# Altium schematic sheet ICWSHLP2_Head_2x2_ASIC0.SchDoc(ICWSHLP2_Head_2x2_ASIC0)
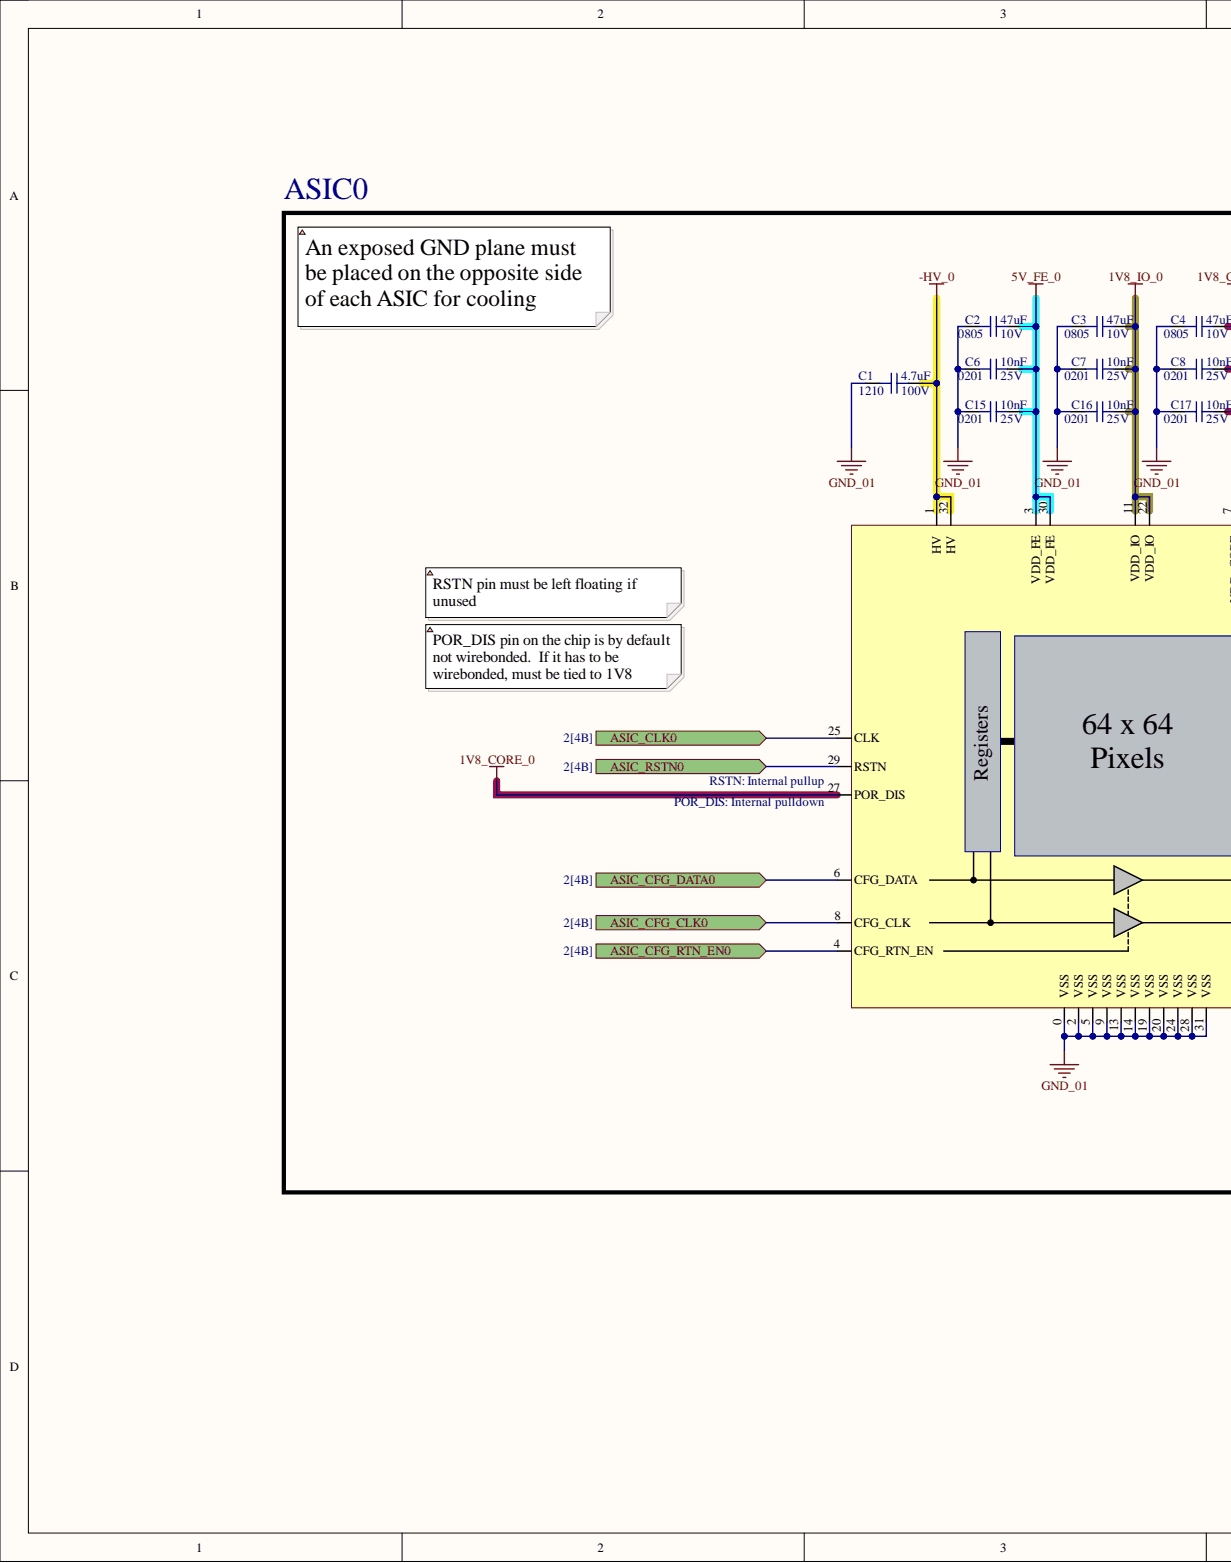
[diagram p0: ICWSHLP2_Head_2x2_ASIC0.SchDoc, left]
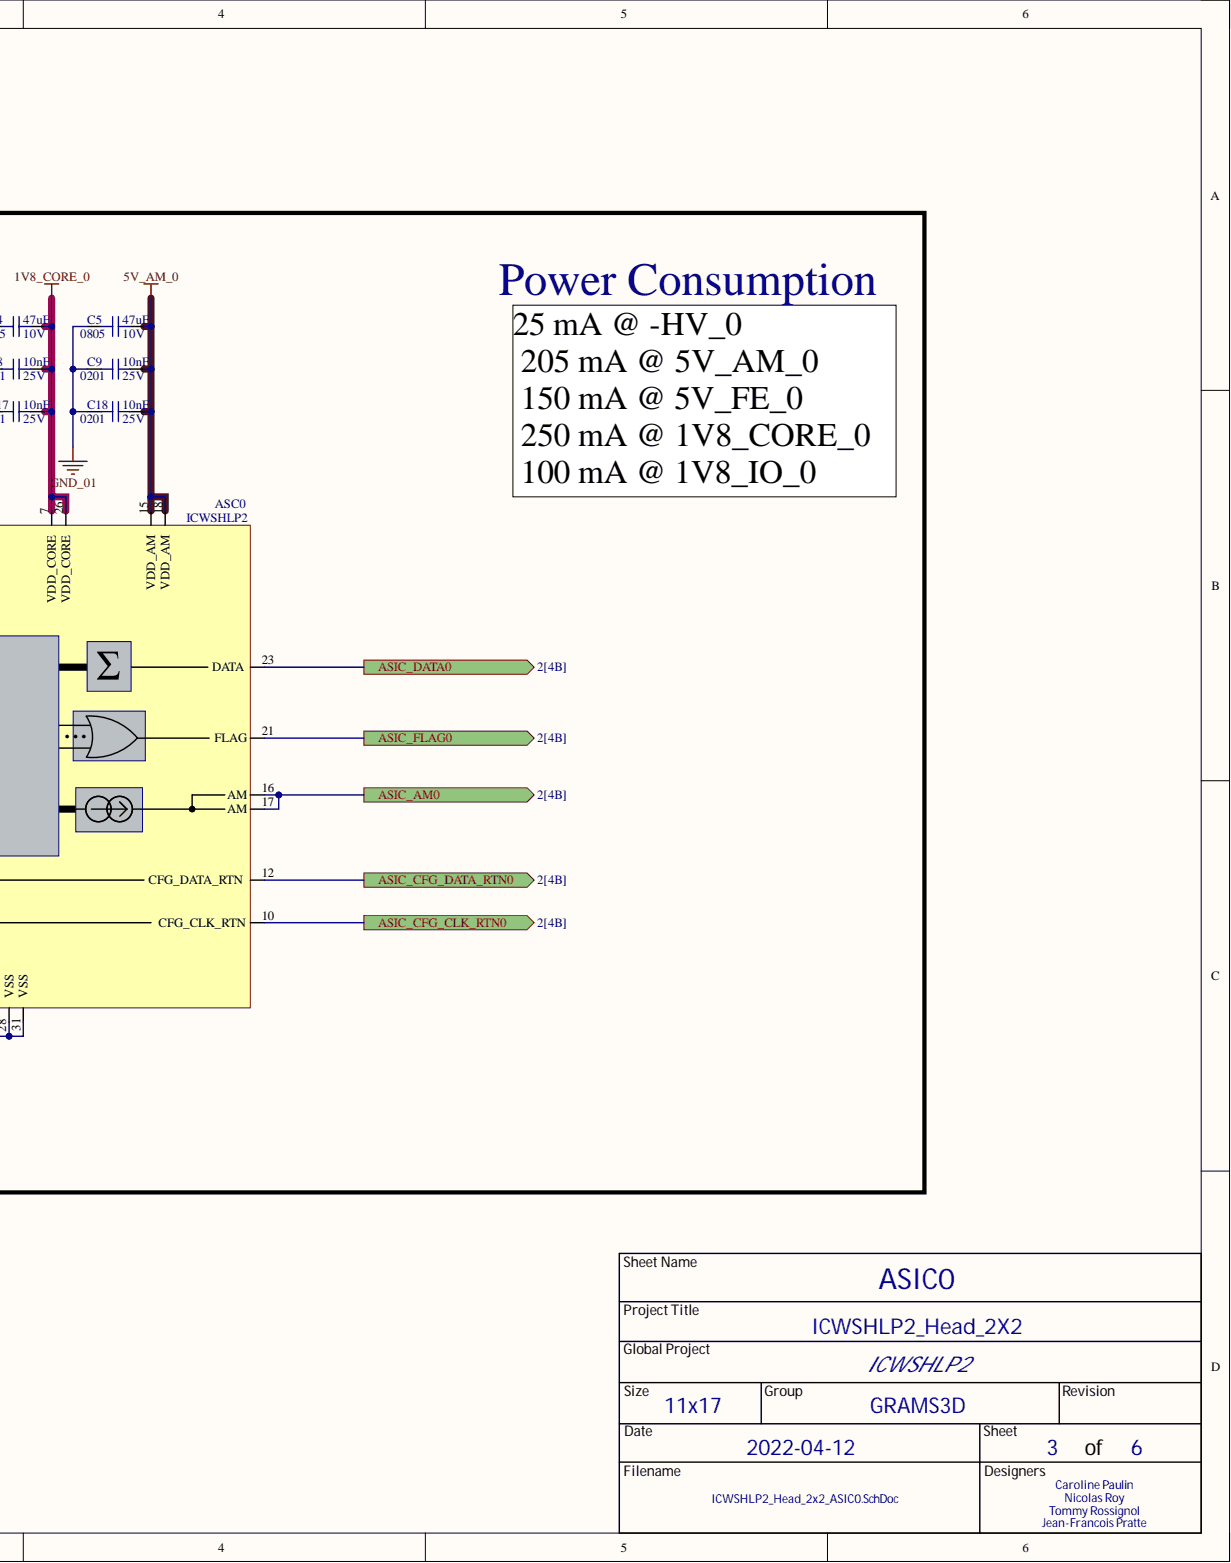
[diagram p1: ICWSHLP2_Head_2x2_ASIC0.SchDoc, right]

## Parts
ASC0  ICWSHLP2  [ASC_ICWSHLP2]
C1  value 4.7uF  Package: 1210  Voltage Rating: 100V  [CAP_CER_1210]  (hidden comment: GCM32DC72A475KE02L)
C2  value 47uF  Package: 0805  Voltage Rating: 10V  [CAP_CER_0805]  (hidden comment: GRM21BR61A476ME15L)
C3  value 47uF  Package: 0805  Voltage Rating: 10V  [CAP_CER_0805]  (hidden comment: GRM21BR61A476ME15L)
C4  value 47uF  Package: 0805  Voltage Rating: 10V  [CAP_CER_0805]  (hidden comment: GRM21BR61A476ME15L)
C5  value 47uF  Package: 0805  Voltage Rating: 10V  [CAP_CER_0805]  (hidden comment: GRM21BR61A476ME15L)
C6  value 10nF  Package: 0201  Voltage Rating: 25V  [CAP_CER_0201]  (hidden comment: TMK063B7103KP-F)
C7  value 10nF  Package: 0201  Voltage Rating: 25V  [CAP_CER_0201]  (hidden comment: TMK063B7103KP-F)
C8  value 10nF  Package: 0201  Voltage Rating: 25V  [CAP_CER_0201]  (hidden comment: TMK063B7103KP-F)
C9  value 10nF  Package: 0201  Voltage Rating: 25V  [CAP_CER_0201]  (hidden comment: TMK063B7103KP-F)
C15  value 10nF  Package: 0201  Voltage Rating: 25V  [CAP_CER_0201]  (hidden comment: TMK063B7103KP-F)
C16  value 10nF  Package: 0201  Voltage Rating: 25V  [CAP_CER_0201]  (hidden comment: TMK063B7103KP-F)
C17  value 10nF  Package: 0201  Voltage Rating: 25V  [CAP_CER_0201]  (hidden comment: TMK063B7103KP-F)
C18  value 10nF  Package: 0201  Voltage Rating: 25V  [CAP_CER_0201]  (hidden comment: TMK063B7103KP-F)

## Ports
ASIC_AM0, ASIC_CFG_CLK0, ASIC_CFG_CLK_RTN0, ASIC_CFG_DATA0, ASIC_CFG_DATA_RTN0, ASIC_CFG_RTN_EN0, ASIC_CLK0, ASIC_DATA0, ASIC_FLAG0, ASIC_RSTN0

## Nets
1V8_CORE_0: ASC0.7(VDD_CORE) ASC0.26(VDD_CORE) ASC0.27(POR_DIS) C4.2 C8.1 C17.1
1V8_IO_0: ASC0.11(VDD_IO) ASC0.22(VDD_IO) C3.2 C7.1 C16.1
5V_AM_0: ASC0.15(VDD_AM) ASC0.18(VDD_AM) C5.2 C9.1 C18.1
5V_FE_0: ASC0.3(VDD_FE) ASC0.30(VDD_FE) C2.2 C6.1 C15.1
-HV_0: ASC0.1(HV) ASC0.32(HV) C1.1
GND_01: ASC0.0(VSS) ASC0.2(VSS) ASC0.5(VSS) ASC0.9(VSS) ASC0.13(VSS) ASC0.14(VSS) ASC0.19(VSS) ASC0.20(VSS) ASC0.24(VSS) ASC0.28(VSS) ASC0.31(VSS) C1.2 C2.1 C3.1 C4.1 C5.1 C6.2 C7.2 C8.2 C9.2 C15.2 C16.2 C17.2 C18.2
ASIC_AM0: ASC0.16(AM) ASC0.17(AM) ; port ASIC_AM0
ASIC_CFG_CLK0: ASC0.8(CFG_CLK) ; port ASIC_CFG_CLK0
ASIC_CFG_CLK_RTN0: ASC0.10(CFG_CLK_RTN) ; port ASIC_CFG_CLK_RTN0
ASIC_CFG_DATA0: ASC0.6(CFG_DATA) ; port ASIC_CFG_DATA0
ASIC_CFG_DATA_RTN0: ASC0.12(CFG_DATA_RTN) ; port ASIC_CFG_DATA_RTN0
ASIC_CFG_RTN_EN0: ASC0.4(CFG_RTN_EN) ; port ASIC_CFG_RTN_EN0
ASIC_CLK0: ASC0.25(CLK) ; port ASIC_CLK0
ASIC_DATA0: ASC0.23(DATA) ; port ASIC_DATA0
ASIC_FLAG0: ASC0.21(FLAG) ; port ASIC_FLAG0
ASIC_RSTN0: ASC0.29(RSTN) ; port ASIC_RSTN0

## Library data of the parts
ASC0 = ASC_ICWSHLP2: Rev 2. CMOS SPAD Readout for 3D PDC in Low Power Applications.; Manufacturer #1: TSMC 180 nm BCD; GRAMS Lib Spec: 2.7; Magnetic Material: No; Polarized: Yes; Voltage Rating: 1.8V/5.0V; Assembly Type: Wirebonding; Component Kind: Standard; Library Name: GRAMS_MASTER_LIB_SCH.SchLib; Package: Bare Die; Part Number #1: ICWSHLP2; Supplier 1: TSMC; Supplier Part Number 1: ICWSHLP2; Temperature: -200 to 125 degC; CreatedBy/Date: Nicolas Roy/24 sept. 2018; VerifiedBy: Nicolas Roy
C1 = CAP_CER_GCM32DC72A475KE02L: CAP CER 4.7UF 100V X7S 1210; Manufacturer #1: Murata Electronics; Cryo: UNKNOWN; GRAMS Lib Spec: 2.8; Polarized: NO; Tolerance: 5%; Assembly Type: SMD; Component Kind: Standard; HelpURL: https://search.murata.co.jp/Ceramy/image/img/A01X/G101/ENG/GCM32DC72A475KE02-01.pdf; Magnetic Material: UNKNOWN; Part Number #1: GCM32DC72A475KE02L; Solder Paste Type: UNKNOWN; Stencil Thickness (Recommended): UNKNOWN; Supplier 1: Digi-Key; Supplier Part Number 1: 490-14484-1-ND; Temperature: -55°C ~ 125°C; CreatedBy/Date: Thomas G-C 2021-07-01; Library Name: Lib_temp_Thomas_GC.SchLib
C2-C5 = CAP_CER_GRM21BR61A476ME15L: CAP - CER 47uF 20% 10V X5R - 0805; Manufacturer #1: Murata; Cryo: UNKNOWN; GRAMS Lib Spec: 2.8; Polarized: No; Assembly Type: SMD; Component Kind: Standard; HelpURL: http://search.murata.co.jp/Ceramy/image/img/A01X/G101/ENG/GRM21BR61A476ME15-01.pdf; Library Name: GRAMS_MASTER_LIB_SCH.SchLib; Magnetic Material: UNKNOWN; Part Number #1: GRM21BR61A476ME15L; Solder Paste Type: UNKNOWN; Stencil Thickness (Recommended): UNKNOWN; Supplier 1: Digi-Key; Supplier Part Number 1: 490-9961-1-ND; Temperature: -55°C ~ 85°C; Tolerance: 20%; CreatedBy/Date: Joel Lemay 2018-06-19; VerifiedBy: Caroline Paulin - 7 sept 2018
C6-C9 C15-C18 = CAP_CER_TMK063B7103KP-F: CAP - CER 10nF 10% 25V X7R - 0201; Manufacturer #1: Taiyo Yuden; Cryo: UNKNOWN; GRAMS Lib Spec: 2.8; Polarized: No; Solder Paste Type: TYPE 3; Stencil Thickness (Recommended): MAX 5 MILS; Assembly Type: SMD; Component Kind: Standard; HelpURL: http://ds.yuden.co.jp/TYCOMPAS/ut/detail.do?productNo=TMK063B7103KP-F&fileName=TMK063B7103KP-F_SS&mode=specSheetDownload; Library Name: GRAMS_MASTER_LIB_SCH.SchLib; Magnetic Material: UNKNOWN; Part Number #1: TMK063B7103KP-F; Supplier 1: Digi-Key; Supplier Part Number 1: 587-5943-1-ND; Temperature: -55°C ~ 125°C; Tolerance: 10%; CreatedBy/Date: Nicolas Roy - 13 dec. 2018; VerifiedBy: Nicolas V. (2019-01-22)
